# Altium schematic sheet GateDriver.SchDoc(GateDriver)
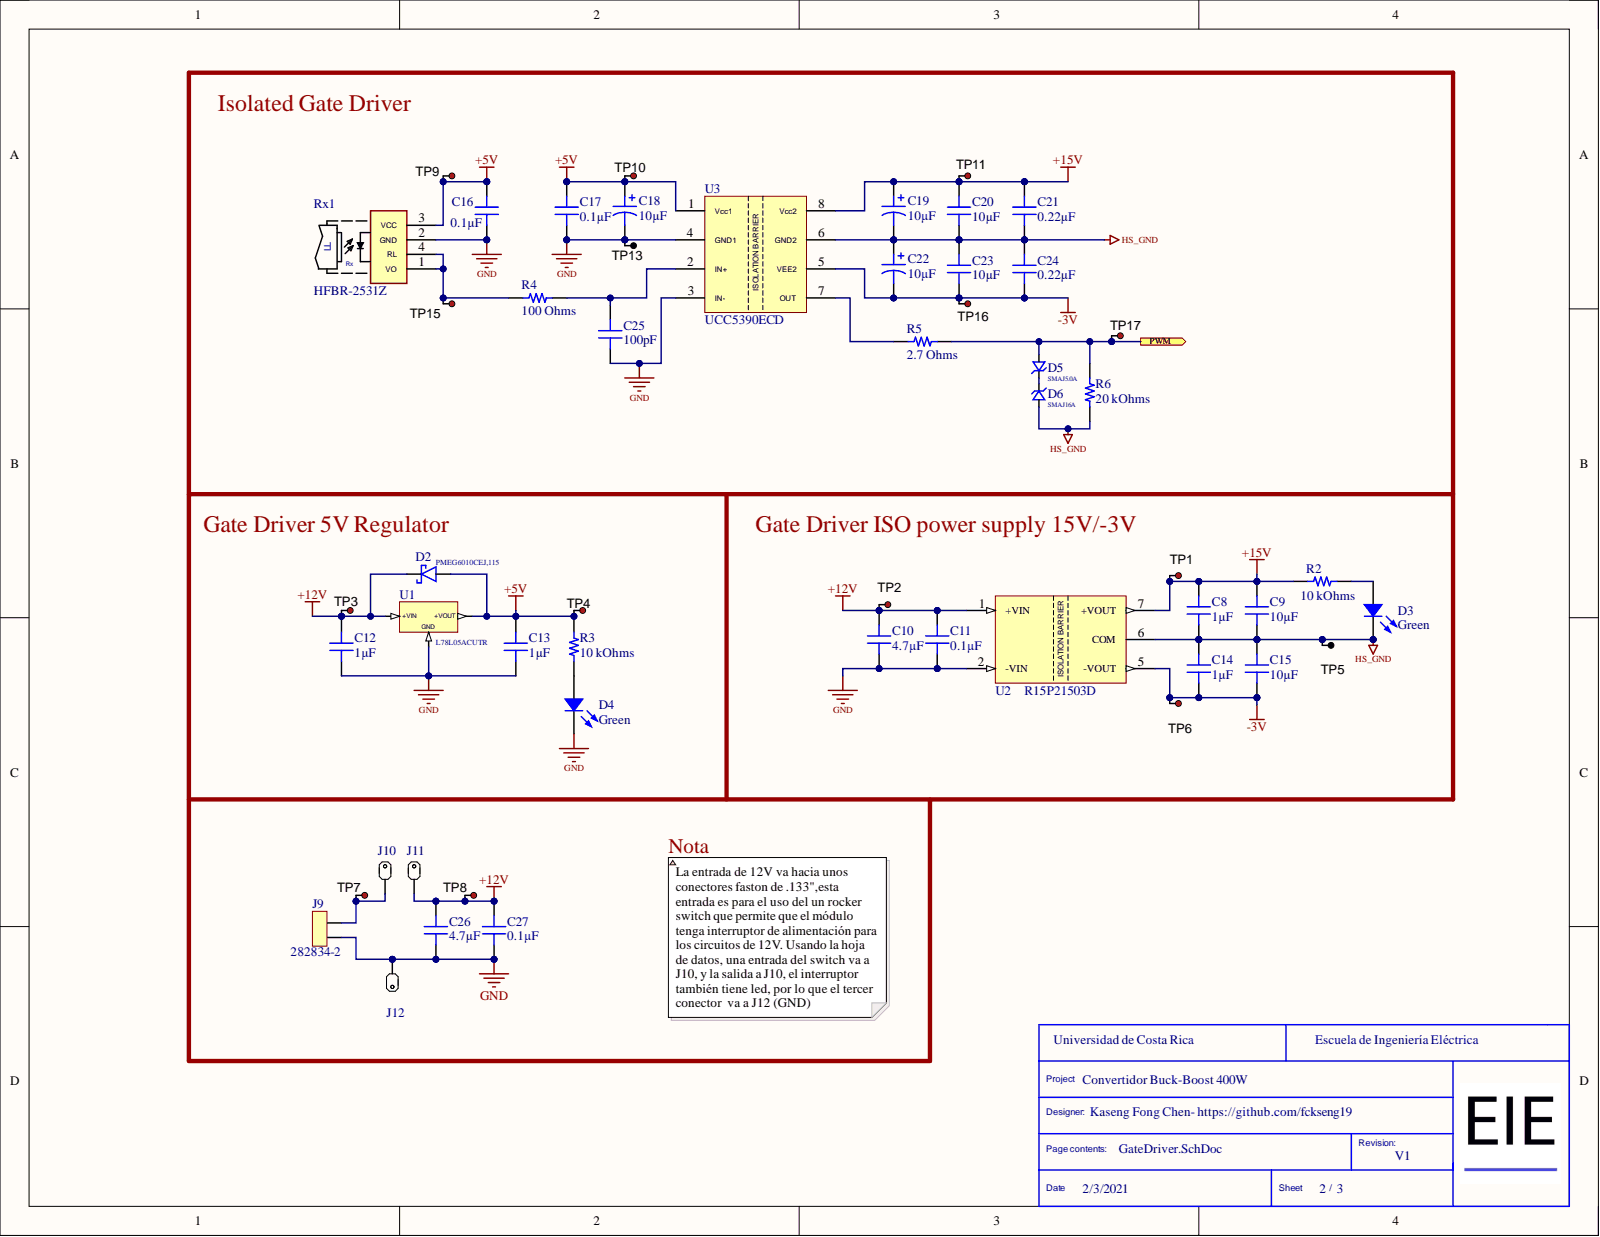
[diagram p0: GateDriver.SchDoc]

## Parts
C8  1µF  [CAP 0603_1608]
C9  10µF  [CAP 1206_3216 - 1.8MM]
C10  4.7µF  [CAP 1206_3216 - 1.8MM]
C11  0.1µF  [CAP 0603_1608]
C12  1µF  [CAP 0603_1608]
C13  1µF  [CAP 0603_1608]
C14  1µF  [CAP 0603_1608]
C15  10µF  [CAP 1206_3216 - 1.8MM]
C16  0.1µF  [CAP 0603_1608]
C17  0.1µF  [CAP 0603_1608]
C18  10µF  [CAP POL 2917_7343]
C19  10µF  [CAP POL 2917_7343]
C20  10µF  [CAP 1210_3225 - 2.8MM]
C21  0.22µF  [CAP 0805_2012]
C22  10µF  [CAP POL 2917_7343]
C23  10µF  [CAP 1210_3225 - 2.8MM]
C24  0.22µF  [CAP 0805_2012]
C25  100pF  [CAP 0603_1608]
C26  4.7µF  [CAP 1206_3216 - 1.8MM]
C27  0.1µF  [CAP 0603_1608]
D2  PMEG6010CEJ,115  [SOD-323F]
D3  Green  [LED 0603_1608 GREEN]
D4  Green  [LED 0603_1608 GREEN]
D5  SMAJ5.0A  [DO214AC SMA]
D6  SMAJ16A  [DO214AC SMA]
J9  282834-2  [TE 282834-2]
J10  Faston .133  (value not drawn)
J11  Faston .133  (value not drawn)
J12  Faston .133  (value not drawn)
R2  10 kOhms  [RES 0603_1608]
R3  10 kOhms  [RES 0603_1608]
R4  100 Ohms  [RES 0603_1608]
R5  2.7 Ohms  [RES 1206_3216]
R6  20 kOhms  [RES 0603_1608]
Rx1  HFBR-2531Z  [HFBR-15X1V]
TP1  5010  [Keystone5010]  (value not drawn)
TP2  5010  [Keystone5010]  (value not drawn)
TP3  5010  [Keystone5010]  (value not drawn)
TP4  5010  [Keystone5010]  (value not drawn)
TP5  5011  [Keystone5011]  (value not drawn)
TP6  5010  [Keystone5010]  (value not drawn)
TP7  5010  [Keystone5010]  (value not drawn)
TP8  5010  [Keystone5010]  (value not drawn)
TP9  5010  [Keystone5010]  (value not drawn)
TP10  5010  [Keystone5010]  (value not drawn)
TP11  5010  [Keystone5010]  (value not drawn)
TP13  5011  [Keystone5011]  (value not drawn)
TP15  5010  [Keystone5010]  (value not drawn)
TP16  5010  [Keystone5010]  (value not drawn)
TP17  5010  [Keystone5010]  (value not drawn)
U1  L78L05ACUTR  [VREG_L78L12ABUTR]
U2  R15P21503D  [CONV_R15P21503D]
U3  UCC5390ECD  [SOIC127P599X175-8N]

## Ports
PWM

## Nets
+5V: C13.2 C16.2 C17.2 C18.1 D2.2 R3.1 Rx1.3(VCC) TP4.1 TP9.1 TP10.1 U1.1 U3.1(Vcc1)
+12V: C10.2 C11.2 C12.2 C26.2 C27.2 D2.1 J11.1 TP2.1 TP3.1 TP8.1 U1.3 U2.1(+VIN)
+15V: C8.2 C9.2 C19.1 C20.1 C21.1 R2.1 TP1.1 TP11.1 U2.7(+VOUT) U3.8(Vcc2)
-3V: C14.1 C15.1 C22.2 C23.2 C24.2 TP6.1 TP16.1 U2.5(-VOUT) U3.5(VEE2)
GND: C10.1 C11.1 C12.1 C13.1 C16.1 C17.1 C18.2 C25.1 C26.1 C27.1 D4.2 J9.2 J12.1 Rx1.2(GND) TP13.1 U1.2 U2.2(-VIN) U3.3(IN-) U3.4(GND1)
HS_GND: C8.1 C9.1 C14.2 C15.2 C19.2 C20.2 C21.2 C22.1 C23.1 C24.1 D3.2 D6.2 R6.1 TP5.1 U2.6(COM) U3.6(GND2)
PWM: D5.2 R5.2 R6.2 TP17.1 ; port PWM
NetC25_2: C25.2 R4.2 U3.2(IN+)
NetD3_1: D3.1 R2.2
NetD4_1: D4.1 R3.2
NetD5_1: D5.1 D6.1
NetJ9_1: J9.1 J10.1 TP7.1
NetR4_1: R4.1 Rx1.1(VO) Rx1.4(RL) TP15.1
NetR5_1: R5.1 U3.7(OUT)

## Library data of the parts
C8 C14 = UMK107AB7105KA-T: CAP CER 1UF 50V X7R 0603; Manufacturer: Taiyo Yuden; Database Table Name: Capacitors - Ceramic - 0603; Tolerance: ±10%; Applications: General Purpose; Capacitance: 1µF; Component Kind: Standard; ComponentLink1Description: Datasheet; ComponentLink1URL: https://www.yuden.co.jp/productdata/catalog/mlcc01_e.pdf; ComponentLink2Description: DigiKey Link; ComponentLink2URL: http://digikey.com/product-detail/en/taiyo-yuden/UMK107AB7105KA-T/587-3247-1-ND/3660107; Device Package: 0603 (1608 Metric); LastUpdated: 2/9/2020 01:03:17; Library Name: Celestial Altium Library - altium_library.DbLib; Lifecycle Status: Active; Minimum Order: 1; Mounting Type: Surface Mount, MLCC; Operating Temperature: -55°C ~ 125°C; Package / Case: 0603 (1608 Metric); Packaging: TapeAndReel; Part Number: UMK107AB7105KA-T; Part Status: Active; PartId: 12796; Price: 0.05; Series: M; Size / Dimension: 0.063" L x 0.031" W (1.60mm x 0.80mm); Supplier 1: DigiKey; Supplier Part Number 1: 587-3247-1-ND; Temperature Coefficient: X7R; Thickness (Max): 0.037" (0.95mm); Value: 1µF; Voltage - Rated: 50V
C9 C15 = TMK316AB7106KL-T: CAP CER 10UF 25V X7R 1206; Manufacturer: Taiyo Yuden; Database Table Name: Capacitors - Ceramic - 1206; Tolerance: ±10%; Applications: General Purpose; Capacitance: 10µF; Component Kind: Standard; ComponentLink1Description: Datasheet; ComponentLink1URL: https://www.yuden.co.jp/productdata/catalog/mlcc01_e.pdf; ComponentLink2Description: DigiKey Link; ComponentLink2URL: http://digikey.com/product-detail/en/taiyo-yuden/TMK316AB7106KL-T/587-3486-1-ND/4157519; Device Package: 1206 (3216 Metric); LastUpdated: 2/9/2020 01:05:40; Library Name: Celestial Altium Library - altium_library.DbLib; Lifecycle Status: Active; Minimum Order: 1; Mounting Type: Surface Mount, MLCC; Operating Temperature: -55°C ~ 125°C; Package / Case: 1206 (3216 Metric); Packaging: TapeAndReel; Part Number: TMK316AB7106KL-T; Part Status: Active; PartId: 20052; Price: 0.08; Series: M; Size / Dimension: 0.126" L x 0.063" W (3.20mm x 1.60mm); Supplier 1: DigiKey; Supplier Part Number 1: 587-3486-1-ND; Temperature Coefficient: X7R; Thickness (Max): 0.071" (1.80mm); Value: 10µF; Voltage - Rated: 25V
C10 C26 = UMK316AB7475KL-T: CAP CER 4.7UF 50V X7R 1206; Manufacturer: Taiyo Yuden; Database Table Name: Capacitors - Ceramic - 1206; Tolerance: ±10%; Applications: General Purpose; Capacitance: 4.7µF; Component Kind: Standard; ComponentLink1Description: Datasheet; ComponentLink1URL: https://www.yuden.co.jp/productdata/catalog/mlcc01_e.pdf; ComponentLink2Description: DigiKey Link; ComponentLink2URL: http://digikey.com/product-detail/en/taiyo-yuden/UMK316AB7475KL-T/587-2994-1-ND/2714187; Device Package: 1206 (3216 Metric); LastUpdated: 2/9/2020 01:05:40; Library Name: Celestial Altium Library - altium_library.DbLib; Lifecycle Status: Active; Minimum Order: 1; Mounting Type: Surface Mount, MLCC; Operating Temperature: -55°C ~ 125°C; Package / Case: 1206 (3216 Metric); Packaging: TapeAndReel; Part Number: UMK316AB7475KL-T; Part Status: Active; PartId: 20094; Price: 0.08; Series: M; Size / Dimension: 0.126" L x 0.063" W (3.20mm x 1.60mm); Supplier 1: DigiKey; Supplier Part Number 1: 587-2994-1-ND; Temperature Coefficient: X7R; Thickness (Max): 0.071" (1.80mm); Value: 4.7µF; Voltage - Rated: 50V
C11 C16 C17 C27 = C0603C104M5RACTU: CAP CER 0.1UF 50V X7R 0603; Manufacturer: KEMET; Database Table Name: Capacitors - Ceramic - 0603; Tolerance: ±20%; Applications: Bypass, Decoupling; Capacitance: 0.1µF; Component Kind: Standard; ComponentLink1Description: Datasheet; ComponentLink1URL: https://api.kemet.com/component-edge/download/datasheet/C0603C104M5RACTU.pdf; ComponentLink2Description: DigiKey Link; ComponentLink2URL: http://digikey.com/product-detail/en/kemet/C0603C104M5RACTU/399-7845-1-ND/3471568; Device Package: 0603 (1608 Metric); LastUpdated: 2/9/2020 01:03:01; Library Name: Celestial Altium Library - altium_library.DbLib; Lifecycle Status: Active; Minimum Order: 1; Mounting Type: Surface Mount, MLCC; Operating Temperature: -55°C ~ 125°C; Package / Case: 0603 (1608 Metric); Packaging: TapeAndReel; Part Number: C0603C104M5RACTU; Part Status: Active; PartId: 17854; Price: 0.01; Series: SMD Comm X7R; Size / Dimension: 0.063" L x 0.031" W (1.60mm x 0.80mm); Supplier 1: DigiKey; Supplier Part Number 1: 399-7845-1-ND; Temperature Coefficient: X7R; Thickness (Max): 0.034" (0.87mm); Value: 0.1µF; Voltage - Rated: 50V
C12 = TMK107B7105KA-T: CAP CER 1UF 25V X7R 0603; Manufacturer: Taiyo Yuden; Database Table Name: Capacitors - Ceramic - 0603; Tolerance: ±10%; Applications: General Purpose; Capacitance: 1µF; Component Kind: Standard; ComponentLink1Description: Datasheet; ComponentLink1URL: https://www.yuden.co.jp/productdata/catalog/mlcc01_e.pdf; ComponentLink2Description: DigiKey Link; ComponentLink2URL: http://digikey.com/product-detail/en/taiyo-yuden/TMK107B7105KA-T/587-2984-1-ND/2714177; Device Package: 0603 (1608 Metric); LastUpdated: 2/9/2020 01:03:30; Library Name: Celestial Altium Library - altium_library.DbLib; Lifecycle Status: Active; Minimum Order: 1; Mounting Type: Surface Mount, MLCC; Operating Temperature: -55°C ~ 125°C; Package / Case: 0603 (1608 Metric); Packaging: TapeAndReel; Part Number: TMK107B7105KA-T; Part Status: Active; PartId: 5586; Price: 0.01; Series: M; Size / Dimension: 0.063" L x 0.031" W (1.60mm x 0.80mm); Supplier 1: DigiKey; Supplier Part Number 1: 587-2984-1-ND; Temperature Coefficient: X7R; Thickness (Max): 0.035" (0.90mm); Value: 1µF; Voltage - Rated: 25V
C13 = CC0603KRX7R7BB105: CAP CER 1UF 16V X7R 0603; Manufacturer: Yageo; Database Table Name: Capacitors - Ceramic - 0603; Tolerance: ±10%; Applications: General Purpose; Capacitance: 1µF; Component Kind: Standard; ComponentLink1Description: Datasheet; ComponentLink1URL: https://www.yageo.com/upload/media/product/productsearch/datasheet/mlcc/UPY-GPHC_X7R_6.3V-to-50V_18.pdf; ComponentLink2Description: DigiKey Link; ComponentLink2URL: http://digikey.com/product-detail/en/yageo/CC0603KRX7R7BB105/311-1446-1-ND/2833752; Device Package: 0603 (1608 Metric); LastUpdated: 2/9/2020 01:03:31; Library Name: Celestial Altium Library - altium_library.DbLib; Lifecycle Status: Active; Minimum Order: 1; Mounting Type: Surface Mount, MLCC; Operating Temperature: -55°C ~ 125°C; Package / Case: 0603 (1608 Metric); Packaging: TapeAndReel; Part Number: CC0603KRX7R7BB105; Part Status: Active; PartId: 5233; Price: 0.01; Series: CC; Size / Dimension: 0.063" L x 0.031" W (1.60mm x 0.80mm); Supplier 1: DigiKey; Supplier Part Number 1: 311-1446-1-ND; Temperature Coefficient: X7R; Thickness (Max): 0.035" (0.90mm); Value: 1µF; Voltage - Rated: 16V
C18 C19 C22 = T491D106K050AT: CAP TANT 10UF 10% 50V 2917; Manufacturer: KEMET; Manufacturer Size Code: D; Database Table Name: Capacitors - Tantalum; ESR (Equivalent Series Resistance): 800mOhm; Lifetime @ Temp.: 2000 Hrs @ 125°C; Type: Molded; Capacitance: 10µF; Component Kind: Standard; ComponentLink1Description: Datasheet; ComponentLink1URL: https://api.kemet.com/component-edge/download/datasheet/T491D106K050AT.pdf; ComponentLink2Description: DigiKey Link; ComponentLink2URL: http://digikey.com/product-detail/en/kemet/T491D106K050AT/399-8361-1-ND/3472084; Device Package: 2917 (7343 Metric); Features: General Purpose; Height - Seated (Max): 0.122" (3.10mm); LastUpdated: 2/9/2020 01:16:12; Library Name: Celestial Altium Library - altium_library.DbLib; Lifecycle Status: Active; Minimum Order: 1; Mounting Type: Surface Mount; Operating Temperature: -55°C ~ 125°C; Package / Case: 2917 (7343 Metric); Packaging: TapeAndReel; Part Number: T491D106K050AT; Part Status: Active; PartId: 3121; Price: 0.86; Series: T491; Size / Dimension: 0.287" L x 0.169" W (7.30mm x 4.30mm); Supplier 1: DigiKey; Supplier Part Number 1: 399-8361-1-ND; Tolerance: ±10%; Value: 10µF; Voltage - Rated: 50V
C20 C23 = UMK325C7106MM-T: CAP CER 10UF 50V X7S 1210; Manufacturer: Taiyo Yuden; Database Table Name: Capacitors - Ceramic - 1210; Tolerance: ±20%; Applications: General Purpose; Capacitance: 10µF; Component Kind: Standard; ComponentLink1Description: Datasheet; ComponentLink1URL: //media.digikey.com/pdf/Data%20Sheets/Taiyo%20Yuden%20PDFs%20URL%20links/UMK325C7106MM-T.pdf; ComponentLink2Description: DigiKey Link; ComponentLink2URL: http://digikey.com/product-detail/en/taiyo-yuden/UMK325C7106MM-T/587-1818-1-ND/1465288; Device Package: 1210 (3225 Metric); LastUpdated: 2/9/2020 01:10:51; Library Name: Celestial Altium Library - altium_library.DbLib; Lifecycle Status: Active; Minimum Order: 1; Mounting Type: Surface Mount, MLCC; Operating Temperature: -55°C ~ 125°C; Package / Case: 1210 (3225 Metric); Packaging: TapeAndReel; Part Number: UMK325C7106MM-T; Part Status: Active; PartId: 23921; Price: 0.21; Series: M; Size / Dimension: 0.126" L x 0.098" W (3.20mm x 2.50mm); Supplier 1: DigiKey; Supplier Part Number 1: 587-1818-1-ND; Temperature Coefficient: X7S; Thickness (Max): 0.106" (2.70mm); Value: 10µF; Voltage - Rated: 50V
C21 C24 = UMK212B7224KG-T: CAP CER 0.22UF 50V X7R 0805; Manufacturer: Taiyo Yuden; Database Table Name: Capacitors - Ceramic - 0805; Tolerance: ±10%; Applications: General Purpose; Capacitance: 0.22µF; Component Kind: Standard; ComponentLink1Description: Datasheet; ComponentLink1URL: https://www.yuden.co.jp/productdata/catalog/mlcc01_e.pdf; ComponentLink2Description: DigiKey Link; ComponentLink2URL: http://digikey.com/product-detail/en/taiyo-yuden/UMK212B7224KG-T/587-1287-1-ND/931064; Device Package: 0805 (2012 Metric); LastUpdated: 2/9/2020 01:04:19; Library Name: Celestial Altium Library - altium_library.DbLib; Lifecycle Status: Active; Minimum Order: 1; Mounting Type: Surface Mount, MLCC; Operating Temperature: -55°C ~ 125°C; Package / Case: 0805 (2012 Metric); Packaging: TapeAndReel; Part Number: UMK212B7224KG-T; Part Status: Active; PartId: 14273; Price: 0.03; Series: M; Size / Dimension: 0.079" L x 0.049" W (2.00mm x 1.25mm); Supplier 1: DigiKey; Supplier Part Number 1: 587-1287-1-ND; Temperature Coefficient: X7R; Thickness (Max): 0.053" (1.35mm); Value: 0.22µF; Voltage - Rated: 50V
C25 = 06035A101FAT2A: CAP CER 100PF 50V C0G/NP0 0603; Manufacturer: AVX Corporation; Database Table Name: Capacitors - Ceramic - 0603; Temperature Coefficient: C0G, NP0; Tolerance: ±1%; Applications: General Purpose; Capacitance: 100pF; Component Kind: Standard; ComponentLink1Description: Datasheet; ComponentLink1URL: http://datasheets.avx.com/C0GNP0-Dielectric.pdf; ComponentLink2Description: DigiKey Link; ComponentLink2URL: http://digikey.com/product-detail/en/avx-corporation/06035A101FAT2A/478-6202-1-ND/2391401; Device Package: 0603 (1608 Metric); LastUpdated: 2/9/2020 01:03:14; Library Name: Celestial Altium Library - altium_library.DbLib; Lifecycle Status: Active; Minimum Order: 1; Mounting Type: Surface Mount, MLCC; Operating Temperature: -55°C ~ 125°C; Package / Case: 0603 (1608 Metric); Packaging: TapeAndReel; Part Number: 06035A101FAT2A; Part Status: Active; PartId: 14099; Price: 0.03; Series: -; Size / Dimension: 0.063" L x 0.032" W (1.60mm x 0.81mm); Supplier 1: DigiKey; Supplier Part Number 1: 478-6202-1-ND; Thickness (Max): 0.035" (0.90mm); Value: 100pF; Voltage - Rated: 50V
D2 = PMEG6010CEJ,115: PMEG6010CEH; PMEG6010CEJ - 1 A very low VF MEGA Schottky barrier rectifiers; Manufacturer: Nexperia; =Value: 60V; Category: Rectifier Diodes; Component Kind: Standard; ComponentLink1Description: https://www.mouser.com/ds/2/302/PMEG6010CEH_PMEG6010CEJ-102368.pdf?src-supplier=Mouser; ComponentLink1URL: https://www.mouser.com/ds/2/302/PMEG6010CEH_PMEG6010CEJ-102368.pdf?src-supplier=Mouser; ComponentLink2Description: http://www.farnell.com/datasheets/109684.pdf?src-supplier=Farnell; ComponentLink2URL: http://www.farnell.com/datasheets/109684.pdf?src-supplier=Farnell; ComponentLink3Description: http://www.farnell.com/datasheets/109684.pdf?src-supplier=Newark; ComponentLink3URL: http://www.farnell.com/datasheets/109684.pdf?src-supplier=Newark; ComponentLink4Description: https://assets.nexperia.com/documents/data-sheet/PMEG6010CEH_PMEG6010CEJ.pdf?src-supplier=Digi-Key; ComponentLink4URL: https://assets.nexperia.com/documents/data-sheet/PMEG6010CEH_PMEG6010CEJ.pdf?src-supplier=Digi-Key; Manufacturer Part Number: PMEG6010CEJ,115; Pricing 1: 1=0,35000, 10=0,27200, 100=0,16340, 500=0,15128, 1000=0,10288 (USD); RoHS: Yes; Stock 1: 105369; Supplier 1: Digi-Key; Supplier Part Number 1: 1727-3882-1-ND; Library Name: KasengLIb.SchLib
D3 D4 = 150060GS75000: LED GREEN CLEAR 0603 SMD; Manufacturer: Würth Elektronik; Configuration: Standard; Current - Test: 20mA; Database Table Name: LED - Indication; Lens Color: Colorless; Lens Size: 1.10mm x 0.70mm; Lens Style: Rectangle with Flat Top; Millicandela Rating: 430mcd; Viewing Angle: 140°; Voltage - Forward (Vf) (Typ): 3.2V; Wavelength - Dominant: 520nm; Wavelength - Peak: 515nm; Color: Green; Component Kind: Standard; ComponentLink1Description: Datasheet; ComponentLink1URL: https://katalog.we-online.de/led/datasheet/150060GS75000.pdf; ComponentLink2Description: DigiKey Link; ComponentLink2URL: http://digikey.com/product-detail/en/w-rth-elektronik/150060GS75000/732-4971-1-ND/4489896; Device Package: 0603 (1608 Metric); Height (Max): 0.80mm; LastUpdated: 2/9/2020 01:20:41; Lens Transparency: Clear; Library Name: Celestial Altium Library - altium_library.DbLib; Lifecycle Status: Active; Minimum Order: 1; Mounting Type: Surface Mount; Package / Case: 0603 (1608 Metric); Packaging: TapeAndReel; Part Number: 150060GS75000; Part Status: Active; PartId: 686; Price: 0.10; Series: WL-SMCW; Size / Dimension: 1.60mm L x 0.80mm W; Supplier 1: DigiKey; Supplier Device Package: 0603; Supplier Part Number 1: 732-4971-1-ND; Value: Green
D5 = SMAJ5.0A: BOURNS - SMAJ5.0A - TVS Diode, SMAJ Series, Unidirectional, 5 V, 9.2 V, DO-214AC (SMA), 2 Pins; Breakdown Voltage: 7V; Clamping Voltage: 9.2V; Diode Type: ZENER; Element Configuration: Single; ESD Protection: Yes; Leakage Current: 800uA; Max Power Dissipation: 400W; Max Surge Current: 40A; Min Breakdown Voltage: 6.4V; Number of Channels: 1; Operating Supply Voltage: 3.5V; Peak Pulse Current: 43.5A; Power Line Protection: No; Reverse Standoff Voltage: 5V; Test Current: 10mA; Case/Package: DO-214AC; Category: TVS Diodes; Component Kind: Standard; ComponentLink1Description: https://datasheet.ciiva.com/25624/0900766b8112213b-25624680.pdf?src-supplier=RSComponents; ComponentLink1URL: https://datasheet.ciiva.com/25624/0900766b8112213b-25624680.pdf?src-supplier=RSComponents; ComponentLink2Description: https://datasheet.ciiva.com/333/smaj-333521.pdf?src-supplier=Digi-Key; ComponentLink2URL: https://datasheet.ciiva.com/333/smaj-333521.pdf?src-supplier=Digi-Key; ComponentLink3Description: https://datasheet.ciiva.com/333/smaj-333522.pdf?src-supplier=Digi-Key; ComponentLink3URL: https://datasheet.ciiva.com/333/smaj-333522.pdf?src-supplier=Digi-Key; ComponentLink4Description: https://datasheet.ciiva.com/333/smaj-333523.pdf?src-supplier=Digi-Key; ComponentLink4URL: https://datasheet.ciiva.com/333/smaj-333523.pdf?src-supplier=Digi-Key; ComponentLink5Description: https://datasheet.ciiva.com/3021/smaj-3021400.pdf?src-supplier=Avnet; ComponentLink5URL: https://datasheet.ciiva.com/3021/smaj-3021400.pdf?src-supplier=Avnet; ComponentLink6Description: https://datasheet.ciiva.com/30169/smaj-30169929.pdf?src-supplier=Onlinecomponents.com; ComponentLink6URL: https://datasheet.ciiva.com/30169/smaj-30169929.pdf?src-supplier=Onlinecomponents.com; ComponentLink7Description: https://datasheet.ciiva.com/28409/1695388-28409916.pdf?src-supplier=Element14; ComponentLink7URL: https://datasheet.ciiva.com/28409/1695388-28409916.pdf?src-supplier=Element14; Direction: Unidirectional; Height: 2.42mm; Length: 4.57mm; Manufacturer: Bourns; Manufacturer Part Number: SMAJ5.0A; Max Breakdown Voltage: 7V; Max Operating Temperature: 150°C; Max Reverse Leakage Current: 800uA; Min Operating Temperature: -55°C; Mount: Surface Mount; Number of Elements: 1; Number of Pins: 2; Number of Unidirectional Channels: 1; Package Quantity: 1; Packaging: Tape and Reel; Peak Pulse Power: 400W; Polarity: Unidirectional; Pricing 1: 1=0,49000, 10=0,34300, 100=0,17320, 500=0,14130, 1000=0,10483, 2000=0,08820 (USD); Radiation Hardening: No; REACH SVHC: No SVHC; Resistor Type: Zener; Reverse Breakdown Voltage: 6.4V; RoHS: Yes; RoHS Compliant: Yes; Series: SMAJ; Stock 1: 30981; Supplier 1: Digi-Key; Supplier Part Number 1: SMAJ5.0ABCT-ND; Termination: SMD/SMT; Width: 2.92mm; Library Name: KasengLIb.SchLib
D6 = SMAJ16A: TVS DIODE 16VWM 26VC DO214AC; Manufacturer: Bourns; Breakdown Voltage: 19.7V; Clamping Voltage: 26V; Diode Type: ZENER; Direction: Unidirectional; Element Configuration: Single; Leakage Current: 5uA; Max Power Dissipation: 400W; Max Surge Current: 40A; Min Breakdown Voltage: 17.8V; Number of Channels: 1; Operating Supply Voltage: 3.5V; Peak Pulse Current: 15.3A; Power Line Protection: No; Reverse Standoff Voltage: 16V; Test Current: 1mA; Case/Package: DO-214AC; Category: TVS Diodes; Component Kind: Standard; ComponentLink1Description: https://datasheet.ciiva.com/3022/smaj-3022194.pdf?src-supplier=Avnet; ComponentLink1URL: https://datasheet.ciiva.com/3022/smaj-3022194.pdf?src-supplier=Avnet; ComponentLink2Description: https://datasheet.ciiva.com/3022/smaj-3022195.pdf?src-supplier=Avnet; ComponentLink2URL: https://datasheet.ciiva.com/3022/smaj-3022195.pdf?src-supplier=Avnet; ComponentLink3Description: https://datasheet.ciiva.com/8031/1695388-8031131.pdf?src-supplier=Element14; ComponentLink3URL: https://datasheet.ciiva.com/8031/1695388-8031131.pdf?src-supplier=Element14; ComponentLink4Description: https://datasheet.ciiva.com/28649/1695388-28649163.pdf?src-supplier=Element14; ComponentLink4URL: https://datasheet.ciiva.com/28649/1695388-28649163.pdf?src-supplier=Element14; ComponentLink5Description: https://datasheet.ciiva.com/333/smaj-333452.pdf?src-supplier=Digi-Key; ComponentLink5URL: https://datasheet.ciiva.com/333/smaj-333452.pdf?src-supplier=Digi-Key; ComponentLink6Description: https://datasheet.ciiva.com/333/smaj-333453.pdf?src-supplier=Digi-Key; ComponentLink6URL: https://datasheet.ciiva.com/333/smaj-333453.pdf?src-supplier=Digi-Key; ComponentLink7Description: https://datasheet.ciiva.com/17413/smaj-17413677.pdf?src-supplier=Avnet+Europe; ComponentLink7URL: https://datasheet.ciiva.com/17413/smaj-17413677.pdf?src-supplier=Avnet+Europe; ComponentLink8Description: https://datasheet.ciiva.com/333/smaj-333454.pdf?src-supplier=Digi-Key; ComponentLink8URL: https://datasheet.ciiva.com/333/smaj-333454.pdf?src-supplier=Digi-Key; ComponentLink9Description: https://datasheet.ciiva.com/16237/smaj-16237406.pdf?src-supplier=Onlinecomponents.com; ComponentLink9URL: https://datasheet.ciiva.com/16237/smaj-16237406.pdf?src-supplier=Onlinecomponents.com; Manufacturer Part Number: SMAJ16A; Max Breakdown Voltage: 19.7V; Max Operating Temperature: 150°C; Max Reverse Leakage Current: 5uA; Min Operating Temperature: -55°C; Mount: Surface Mount; Number of Elements: 1; Number of Pins: 2; Number of Unidirectional Channels: 1; Package Quantity: 1; Packaging: Tape and Reel; Peak Pulse Power: 400W; Polarity: Unidirectional; Pricing 1: 1=0,49000, 10=0,34400, 100=0,17360, 500=0,14160, 1000=0,10505, 2000=0,08838 (USD); Radiation Hardening: No; REACH SVHC: No SVHC; Resistor Type: Zener; Reverse Breakdown Voltage: 17.8V; RoHS: Yes; RoHS Compliant: Yes; Series: SMAJ; Stock 1: 14121; Supplier 1: Digi-Key; Supplier Part Number 1: SMAJ16ABCT-ND; Termination: SMD/SMT; Library Name: KasengLIb.SchLib
J9 = 282834-2: TE CONNECTIVITY / BUCHANAN - 282834-2 - TERMINAL BLOCK, WIRE TO BRD, 2POS, 16AWG; Approvals: UL; Closed End: No (Without); Connector Type: Receptacle; Current: 10A; Gender: Male; Max Supply Voltage (AC): 150V; Number of Circuits: 2; Number of Levels: 1; Pitch: 2.54mm; Plating: Brass,Steel; Screwless Terminal Block: Yes (With); Tail Length: 3.4mm; Thread Size: M1.6; Wire Gauge (Max): 30AWG; Category: Terminal Blocks; Color: Green; Component Kind: Standard; ComponentLink1Description: https://datasheet.ciiva.com/11758/0900766b80e7dc96-11758675.pdf?src-supplier=RSComponents; ComponentLink1URL: https://datasheet.ciiva.com/11758/0900766b80e7dc96-11758675.pdf?src-supplier=RSComponents; ComponentLink2Description: https://datasheet.ciiva.com/16386/282834-2-16386363.pdf?src-supplier=Future+Electronics; ComponentLink2URL: https://datasheet.ciiva.com/16386/282834-2-16386363.pdf?src-supplier=Future+Electronics; ComponentLink3Description: https://datasheet.ciiva.com/14609/70086732-14609670.pdf?src-supplier=Allied+Electronics; ComponentLink3URL: https://datasheet.ciiva.com/14609/70086732-14609670.pdf?src-supplier=Allied+Electronics; ComponentLink4Description: https://datasheet.ciiva.com/15169/getdatasheetpartid-155864-15169558.pdf?src-supplier=Verical; ComponentLink4URL: https://datasheet.ciiva.com/15169/getdatasheetpartid-155864-15169558.pdf?src-supplier=Verical; ComponentLink5Description: https://datasheet.ciiva.com/16676/eng-cs-1308389-eurostyle-terminal-blocks-0607-16676126.pdf?src-supplier=Avnet+Europe; ComponentLink5URL: https://datasheet.ciiva.com/16676/eng-cs-1308389-eurostyle-terminal-blocks-0607-16676126.pdf?src-supplier=Avnet+Europe; ComponentLink6Description: https://datasheet.ciiva.com/30166/eng-cs-1308389-eurostyle-terminal-blocks-0607-30166974.pdf?src-supplier=Onlinecomponents.com; ComponentLink6URL: https://datasheet.ciiva.com/30166/eng-cs-1308389-eurostyle-terminal-blocks-0607-30166974.pdf?src-supplier=Onlinecomponents.com; ComponentLink7Description: https://datasheet.ciiva.com/3141/eng-cs-1308389-eurostyle-terminal-blocks-0607-3141115.pdf?src-supplier=Avnet; ComponentLink7URL: https://datasheet.ciiva.com/3141/eng-cs-1308389-eurostyle-terminal-blocks-0607-3141115.pdf?src-supplier=Avnet; ComponentLink8Description: https://datasheet.ciiva.com/823/eng-cd-282834-c1-823453.pdf?src-supplier=Digi-Key; ComponentLink8URL: https://datasheet.ciiva.com/823/eng-cd-282834-c1-823453.pdf?src-supplier=Digi-Key; Contact Material: Brass; Contact Plating: Tin; Current Rating: 10A; Depth: 6.5mm; ELV: Compliant; Flammability Rating: UL94 V-0; Housing Color: Green; Housing Material: Polyamide; Interlock: No (Without); Lead Free: Lead Free; Length: 5.54mm; Manufacturer: TE Connectivity; Manufacturer Part Number: 282834-2; Material: Nylon; Max Current Rating: 10A; Max Operating Temperature: 105°C; Max Voltage Rating (AC): 150V; Min Operating Temperature: -40°C; Mount: Through Hole; Number of Contacts: 2; Number of Poles: 2; Number of Positions: 2; Number of Positions Per Level: 2; Number of Rows: 1; Orientation: Straight; Package Quantity: 300; Packaging: Bulk; Pricing 1: 1=1,27000, 10=0,89500, 25=0,83440, 50=0,75740, 100=0,75410, 250=0,63676, 500=0,60324, 1000=0,53622, 5000=0,50270 (USD); Radiation Hardening: No; REACH SVHC: Unknown; RoHS: Yes; RoHS Compliant: Yes; Screw Flange: No (Without); Series: Buchanan; Side Stackable: Yes (With); Stock 1: 21575; Supplier 1: Digi-Key; Supplier Part Number 1: A98333-ND; Termination: Solder; Voltage: 150V; Voltage Rating: 150V; Width: 6.5mm; Wire Gauge (Min): 30AWG; Wire/Cable Gauge: 30AWG; Library Name: kasengLib.IntLib
J10-J12 = 1217133-1: CONN QC TAB 0.187 SOLDER; Manufacturer: TE Connectivity; Board Thickness: 1.57mm; Body Material: Brass; Body Plating: Tin; Gender: Male; Hole Diameter: 0.052inch; Insulated Wire Support: Yes; Insulation: Non-Insulated; Mating Tab Width: 4.75mm; Outside Diameter: 1.32mm; PCB Thickness: 0.062inch; Tab Thickness: 0.032inch; Tab Width: 0.187inch; Category: Terminals; Component Kind: Standard; ComponentLink1Description: https://datasheet.ciiva.com/3144/eng-cs-82004-faston-pcb-tabs-receptacles-1012-3144672.pdf?src-supplier=Avnet; ComponentLink1URL: https://datasheet.ciiva.com/3144/eng-cs-82004-faston-pcb-tabs-receptacles-1012-3144672.pdf?src-supplier=Avnet; ComponentLink2Description: https://datasheet.ciiva.com/16301/eng-cs-82004-faston-pcb-tabs-receptacles-0311-16301555.pdf?src-supplier=Future+Electronics; ComponentLink2URL: https://datasheet.ciiva.com/16301/eng-cs-82004-faston-pcb-tabs-receptacles-0311-16301555.pdf?src-supplier=Future+Electronics; ComponentLink3Description: https://datasheet.ciiva.com/839/eng-cd-1217133-g-basefilename-839190.pdf?src-supplier=Digi-Key; ComponentLink3URL: https://datasheet.ciiva.com/839/eng-cd-1217133-g-basefilename-839190.pdf?src-supplier=Digi-Key; ComponentLink4Description: https://datasheet.ciiva.com/30183/eng-cat-82004-0706-042-052-30183301.pdf?src-supplier=Onlinecomponents.com; ComponentLink4URL: https://datasheet.ciiva.com/30183/eng-cat-82004-0706-042-052-30183301.pdf?src-supplier=Onlinecomponents.com; ComponentLink5Description: https://datasheet.ciiva.com/16647/eng-cs-82004-faston-pcb-tabs-receptacles-1012-16647017.pdf?src-supplier=Avnet+Europe; ComponentLink5URL: https://datasheet.ciiva.com/16647/eng-cs-82004-faston-pcb-tabs-receptacles-1012-16647017.pdf?src-supplier=Avnet+Europe; ComponentLink6Description: https://datasheet.ciiva.com/839/eng-cd-1217133-g-basefilename-839191.pdf?src-supplier=Digi-Key; ComponentLink6URL: https://datasheet.ciiva.com/839/eng-cd-1217133-g-basefilename-839191.pdf?src-supplier=Digi-Key; Contact Material: Brass; Contact Plating: Tin; Depth: 7.92mm; ELV: Compliant; Lead Free: Lead Free; Length: 12.45mm; Manufacturer Part Number: 1217133-1; Material: Brass; Package Quantity: 10000; Packaging: Tape and Reel; Plating: Tin; Pricing 1: 1=0,14000, 10=0,13300, 25=0,09920, 50=0,08500, 100=0,08210, 250=0,07076, 500=0,06794, 1000=0,05945, 2500=0,05379 (USD); Radiation Hardening: No; RoHS: Yes; Stock 1: 9496; Supplier 1: Digi-Key; Supplier Part Number 1: A100449CT-ND; Termination: Tab; Library Name: KasengLIb.SchLib
R2 R3 = RC0603FR-0710KL: RES SMD 10K OHM 1% 1/10W 0603; Manufacturer: Yageo; Database Table Name: Resistors - Surface Mount - 0603; Power (Watts): 0.1W, 1/10W; Temperature Coefficient: ±100ppm/°C; Component Kind: Standard; ComponentLink1Description: Datasheet; ComponentLink1URL: https://www.yageo.com/upload/media/product/productsearch/datasheet/rchip/PYu-RC_Group_51_RoHS_L_10.pdf; ComponentLink2Description: DigiKey Link; ComponentLink2URL: http://digikey.com/product-detail/en/yageo/RC0603FR-0710KL/311-10.0KHRCT-ND/729827; Composition: Thick Film; Device Package: 0603 (1608 Metric); Features: Moisture Resistant; Height - Seated (Max): 0.022" (0.55mm); LastUpdated: 2/9/2020 01:09:45; Library Name: Celestial Altium Library - altium_library.DbLib; Lifecycle Status: Active; Minimum Order: 1; Number of Terminations: 2; Operating Temperature: -55°C ~ 155°C; Package / Case: 0603 (1608 Metric); Packaging: CutTape; Part Number: RC0603FR-0710KL; Part Status: Active; PartId: 22599; Price: 0.10; Resistance: 10 kOhms; Series: RC; Size / Dimension: 0.063" L x 0.031" W (1.60mm x 0.80mm); Supplier 1: DigiKey; Supplier Device Package: 0603; Supplier Part Number 1: 311-10.0KHRCT-ND; Tolerance: ±1%; Value: 10 kOhms
R4 = CRCW0603100RFKEAC: RES SMD 100 OHM 1% 1/10W 0603; Manufacturer: Vishay Dale; Database Table Name: Resistors - Surface Mount - 0603; Power (Watts): 0.1W, 1/10W; Temperature Coefficient: ±100ppm/°C; Component Kind: Standard; ComponentLink1Description: Datasheet; ComponentLink1URL: http://www.vishay.com/docs/28773/crcwce3.pdf; ComponentLink2Description: DigiKey Link; ComponentLink2URL: http://digikey.com/product-detail/en/vishay-dale/CRCW0603100RFKEAC/541-3951-1-ND/7928634; Composition: Thick Film; Device Package: 0603 (1608 Metric); Height - Seated (Max): 0.022" (0.55mm); LastUpdated: 2/9/2020 01:10:09; Library Name: Celestial Altium Library - altium_library.DbLib; Lifecycle Status: Active; Minimum Order: 1; Number of Terminations: 2; Operating Temperature: -55°C ~ 155°C; Package / Case: 0603 (1608 Metric); Packaging: CutTape; Part Number: CRCW0603100RFKEAC; Part Status: Active; PartId: 12546; Price: 0.10; Resistance: 100 Ohms; Series: CRCW-C; Supplier 1: DigiKey; Supplier Device Package: 0603; Supplier Part Number 1: 541-3951-1-ND; Tolerance: ±1%; Value: 100 Ohms
R5 = CRCW12062R70FKEAHP: RES SMD 2.7 OHM 1% 3/4W 1206; Manufacturer: Vishay Dale; Database Table Name: Resistors - Surface Mount - 1206; Power (Watts): 0.75W, 3/4W; Temperature Coefficient: ±100ppm/°C; Component Kind: Standard; ComponentLink1Description: Datasheet; ComponentLink1URL: http://www.vishay.com/docs/20043/crcwhpe3.pdf; ComponentLink2Description: DigiKey Link; ComponentLink2URL: http://digikey.com/product-detail/en/vishay-dale/CRCW12062R70FKEAHP/541-2.70UCT-ND/5326978; Composition: Thick Film; Device Package: 1206 (3216 Metric); Features: Automotive AEC-Q200, Pulse Withstanding; Height - Seated (Max): 0.024" (0.60mm); LastUpdated: 2/9/2020 01:12:48; Library Name: Celestial Altium Library - altium_library.DbLib; Lifecycle Status: Active; Minimum Order: 1; Number of Terminations: 2; Operating Temperature: -55°C ~ 155°C; Package / Case: 1206 (3216 Metric); Packaging: TapeAndReel; Part Number: CRCW12062R70FKEAHP; Part Status: Active; PartId: 36112; Price: 0.06; Ratings: AEC-Q200; Resistance: 2.7 Ohms; Series: CRCW-HP; Size / Dimension: 0.122" L x 0.063" W (3.10mm x 1.60mm); Supplier 1: DigiKey; Supplier Device Package: 1206; Supplier Part Number 1: 541-2.70UCT-ND; Tolerance: ±1%; Value: 2.7 Ohms
R6 = RC0603FR-0720KL: RES SMD 20K OHM 1% 1/10W 0603; Manufacturer: Yageo; Database Table Name: Resistors - Surface Mount - 0603; Power (Watts): 0.1W, 1/10W; Temperature Coefficient: ±100ppm/°C; Component Kind: Standard; ComponentLink1Description: Datasheet; ComponentLink1URL: https://www.yageo.com/upload/media/product/productsearch/datasheet/rchip/PYu-RC_Group_51_RoHS_L_10.pdf; ComponentLink2Description: DigiKey Link; ComponentLink2URL: http://digikey.com/product-detail/en/yageo/RC0603FR-0720KL/311-20.0KHRCT-ND/729987; Composition: Thick Film; Device Package: 0603 (1608 Metric); Features: Moisture Resistant; Height - Seated (Max): 0.022" (0.55mm); LastUpdated: 2/9/2020 01:09:40; Library Name: Celestial Altium Library - altium_library.DbLib; Lifecycle Status: Active; Minimum Order: 1; Number of Terminations: 2; Operating Temperature: -55°C ~ 155°C; Package / Case: 0603 (1608 Metric); Packaging: CutTape; Part Number: RC0603FR-0720KL; Part Status: Active; PartId: 23954; Price: 0.10; Resistance: 20 kOhms; Series: RC; Size / Dimension: 0.063" L x 0.031" W (1.60mm x 0.80mm); Supplier 1: DigiKey; Supplier Device Package: 0603; Supplier Part Number 1: 311-20.0KHRCT-ND; Tolerance: ±1%; Value: 20 kOhms
Rx1 = HFBR-2531Z: RX Optical Fiber 5MBd 6-Pin; Manufacturer: Broadcom Avago; Data Rate: 115Kbps; Fall Time: 40ns; Max Output Current: 25mA; Max Power Dissipation: 40mW; Max Supply Voltage: 7V; Min Supply Voltage: -500mV; Operating Supply Current: 10mA; Operating Supply Voltage: 5V; Rise Time: 80ns; Wavelength: 660nm; Category: Fiber Optics; Component Kind: Standard; Height: 18.8mm; Lead Free: Lead Free; Length: 18.3mm; Manufacturer Part Number: HFBR-2531Z; Max Operating Temperature: 85°C; Min Operating Temperature: -40°C; Mount: Through Hole; Number of Pins: 6; Output Current: 25mA; Package Quantity: 200; Packaging: Rail/Tube; Pricing 1: 1=12,98000, 10=9,79400, 100=8,22730, 500=7,55568, 1000=7,39200 (USD); Radiation Hardening: No; REACH SVHC: No SVHC; RoHS: Yes; RoHS Compliant: Yes; Series: HFBR-2; Stock 1: 6696; Supplier 1: Digi-Key; Supplier Part Number 1: 516-2067-ND; Weight: 0.021164oz; Width: 12.2mm; Library Name: KasengLIb.SchLib
TP1-TP4 TP6-TP11 TP15-TP17 = 5010: Test Point, Multipurpose, Red, TH; Manufacturer: Keystone; CompType: Test Point Loop; ContactFinish: Silver; Color: Red; Component Kind: Standard; ComponentLink1Description: Datasheet URL; ComponentLink1URL: http://www.keyelco.com/userAssets/file/M60-2p60.pdf; LatestRevisionDate: 2016-02-11; MountType: TH; PackageReference: Red Multipurpose Testpoint; PartNumber: 5010; RoHS: Y; Supplier 1: Digi-Key; Supplier 2: Mouser; Supplier Part Number 1: 36-5010-ND; Supplier Part Number 2: 534-5010; SymbolVersion: 2008-04-04; Library Name: kasengLib.IntLib; Publisher: Texas Instruments
TP5 TP13 = 5011: Test Point, Multipurpose, Black, TH; Manufacturer: Keystone; CompType: Test Point Loop; ContactFinish: Silver; Color: Black; Component Kind: Standard; ComponentLink1Description: Datasheet URL; ComponentLink1URL: http://www.keyelco.com/userAssets/file/M60-2p60.pdf; LatestRevisionDate: 2016-02-11; MountType: TH; PackageReference: Black Multipurpose Testpoint; PartNumber: 5011; RoHS: Y; Supplier 1: Digi-Key; Supplier 2: Mouser; Supplier Part Number 1: 36-5011-ND; Supplier Part Number 2: 534-5011; SymbolVersion: 2008-04-04; Library Name: kasengLib.IntLib; Publisher: Texas Instruments
U1 = L78L05ACUTR: Voltage regulator; linear, fixed; 5V; 0.1A; SOT89; SMD; 0÷125°C; Manufacturer: STMicroelectronics; Accuracy: 4%; Dropout Voltage: 1.7V; Max Input Voltage: 30V; Max Output Current: 100mA; Min Input Voltage: 7V; Number of Outputs: 1; Polarity: Positive; Power Supply Rejection Ratio (PSRR): 49dB; Quiescent Current: 6mA; Case/Package: SOT-89; Category: Voltage Regulators - Linear; Component Kind: Standard; ComponentLink1Description: https://datasheet.ciiva.com/2041/cd00000446-2041792.pdf?src-supplier=Digi-Key; ComponentLink1URL: https://datasheet.ciiva.com/2041/cd00000446-2041792.pdf?src-supplier=Digi-Key; ComponentLink2Description: https://datasheet.ciiva.com/1511/1868798-1511940.pdf?src-supplier=Element14; ComponentLink2URL: https://datasheet.ciiva.com/1511/1868798-1511940.pdf?src-supplier=Element14; ComponentLink3Description: https://datasheet.ciiva.com/7992/1868798-7992600.pdf?src-supplier=Element14; ComponentLink3URL: https://datasheet.ciiva.com/7992/1868798-7992600.pdf?src-supplier=Element14; ComponentLink4Description: https://datasheet.ciiva.com/16393/cd00000446-16393708.pdf?src-supplier=Future+Electronics; ComponentLink4URL: https://datasheet.ciiva.com/16393/cd00000446-16393708.pdf?src-supplier=Future+Electronics; ComponentLink5Description: https://datasheet.ciiva.com/22710/1868798-22710940.pdf?src-supplier=Element14; ComponentLink5URL: https://datasheet.ciiva.com/22710/1868798-22710940.pdf?src-supplier=Element14; ComponentLink6Description: https://datasheet.ciiva.com/4882/cd00000446-355303-4882429.pdf?src-supplier=Mouser; ComponentLink6URL: https://datasheet.ciiva.com/4882/cd00000446-355303-4882429.pdf?src-supplier=Mouser; ComponentLink7Description: https://datasheet.ciiva.com/2041/cd00000446-2041790.pdf?src-supplier=Digi-Key; ComponentLink7URL: https://datasheet.ciiva.com/2041/cd00000446-2041790.pdf?src-supplier=Digi-Key; ComponentLink8Description: https://datasheet.ciiva.com/2041/cd00000446-2041791.pdf?src-supplier=Digi-Key; ComponentLink8URL: https://datasheet.ciiva.com/2041/cd00000446-2041791.pdf?src-supplier=Digi-Key; Contact Plating: Tin,Matte; Height: 1.6mm; Lead Free: Lead Free; Length: 4.6mm; Manufacturer Part Number: L78L05ACUTR; Max Operating Temperature: 125°C; Min Operating Temperature: 0°C; Min Output Voltage: 5V; Mount: Surface Mount; Nominal Output Voltage: 5V; Number of Pins: 3; Number of Regulators: 1; Output Current: 100mA; Output Type: Fixed; Output Voltage: 5V; Output Voltage Accuracy: 4%; Package Quantity: 1; Packaging: Tape and Reel; Pricing 1: 1=0,53000, 10=0,43300, 25=0,39600, 100=0,26710, 250=0,22108, 500=0,20266, 1000=0,17380 (USD); Radiation Hardening: No; REACH SVHC: No SVHC; RoHS: Yes; RoHS Compliant: Yes; Series: -; Stock 1: 40018; Supplier 1: Digi-Key; Supplier Part Number 1: 497-1183-1-ND; Weight: 0.004603oz; Width: 2.6mm; Library Name: KasengLIb.SchLib
U2 = R15P21503D: 2W DC/DC-Converter 'ECONOLINE' SIP7 6.4kV unreg; V. In: 15; V. Out: +15/-03; mA. Out: +93/-185; Manufacturer: Recom; Max Output Voltage: 15V; Category: Voltage Regulators - Switching; Component Kind: Standard; ComponentLink1Description: https://www.recom-power.com/pdf/Econoline/RxxP2xxyy.pdf?src-supplier=Digi-Key; ComponentLink1URL: https://www.recom-power.com/pdf/Econoline/RxxP2xxyy.pdf?src-supplier=Digi-Key; Height: 12.5mm; Length: 19.5mm; Manufacturer Part Number: R15P21503D; Pricing 1: 1=8,47000, 5=8,31800, 10=8,25500, 25=8,12800, 50=7,87400, 100=7,26000, 250=6,98500, 500=6,85800 (USD); RoHS: Yes; RoHS Compliant: Yes; Stock 1: 1614; Supplier 1: Digi-Key; Supplier Part Number 1: 945-3070-5-ND; Weight: 4.3g; Width: 9.8mm; Library Name: KasengLIb.SchLib
U3 = UCC5390ECD: 10-A/10-A, 3-k/5-kVRMS single-channel isolated gate driver with 12-V UVLO, bipolar supply 8-SOIC -40 to 125; Manufacturer: Texas Instruments; Approvals: CSA,VDE,UR,CQC; High Level Output Current: 10A; Number of Channels: 1; Propagation Delay: 100ns; Pulse Width Distortion (PWD): 20ns; Working Voltage: 990V; Case/Package: SOIC; Category: Integrated Circuits (ICs); Component Kind: Standard; ComponentLink1Description: http://www.ti.com/lit/ds/symlink/ucc5390.pdf?src-supplier=Digi-Key; ComponentLink1URL: http://www.ti.com/lit/ds/symlink/ucc5390.pdf?src-supplier=Digi-Key; Low Level Output Current: 10A; Manufacturer Part Number: UCC5390ECD; Max Operating Temperature: 125°C; Min Operating Temperature: -40°C; Mount: Surface Mount; Packaging: Rail/Tube; Pricing 1: 1=2,81000, 10=2,52800, 25=2,38480, 100=1,90800, 250=1,66952, 500=1,62180, 1000=1,28790, 2500=1,26000 (USD); RoHS: Yes; RoHS Compliant: Yes; Stock 1: 1559; Supplier 1: Digi-Key; Supplier Part Number 1: 296-48101-ND; Library Name: kasengLib.IntLib
